# Altium schematic sheet DigitalPower.SchDoc
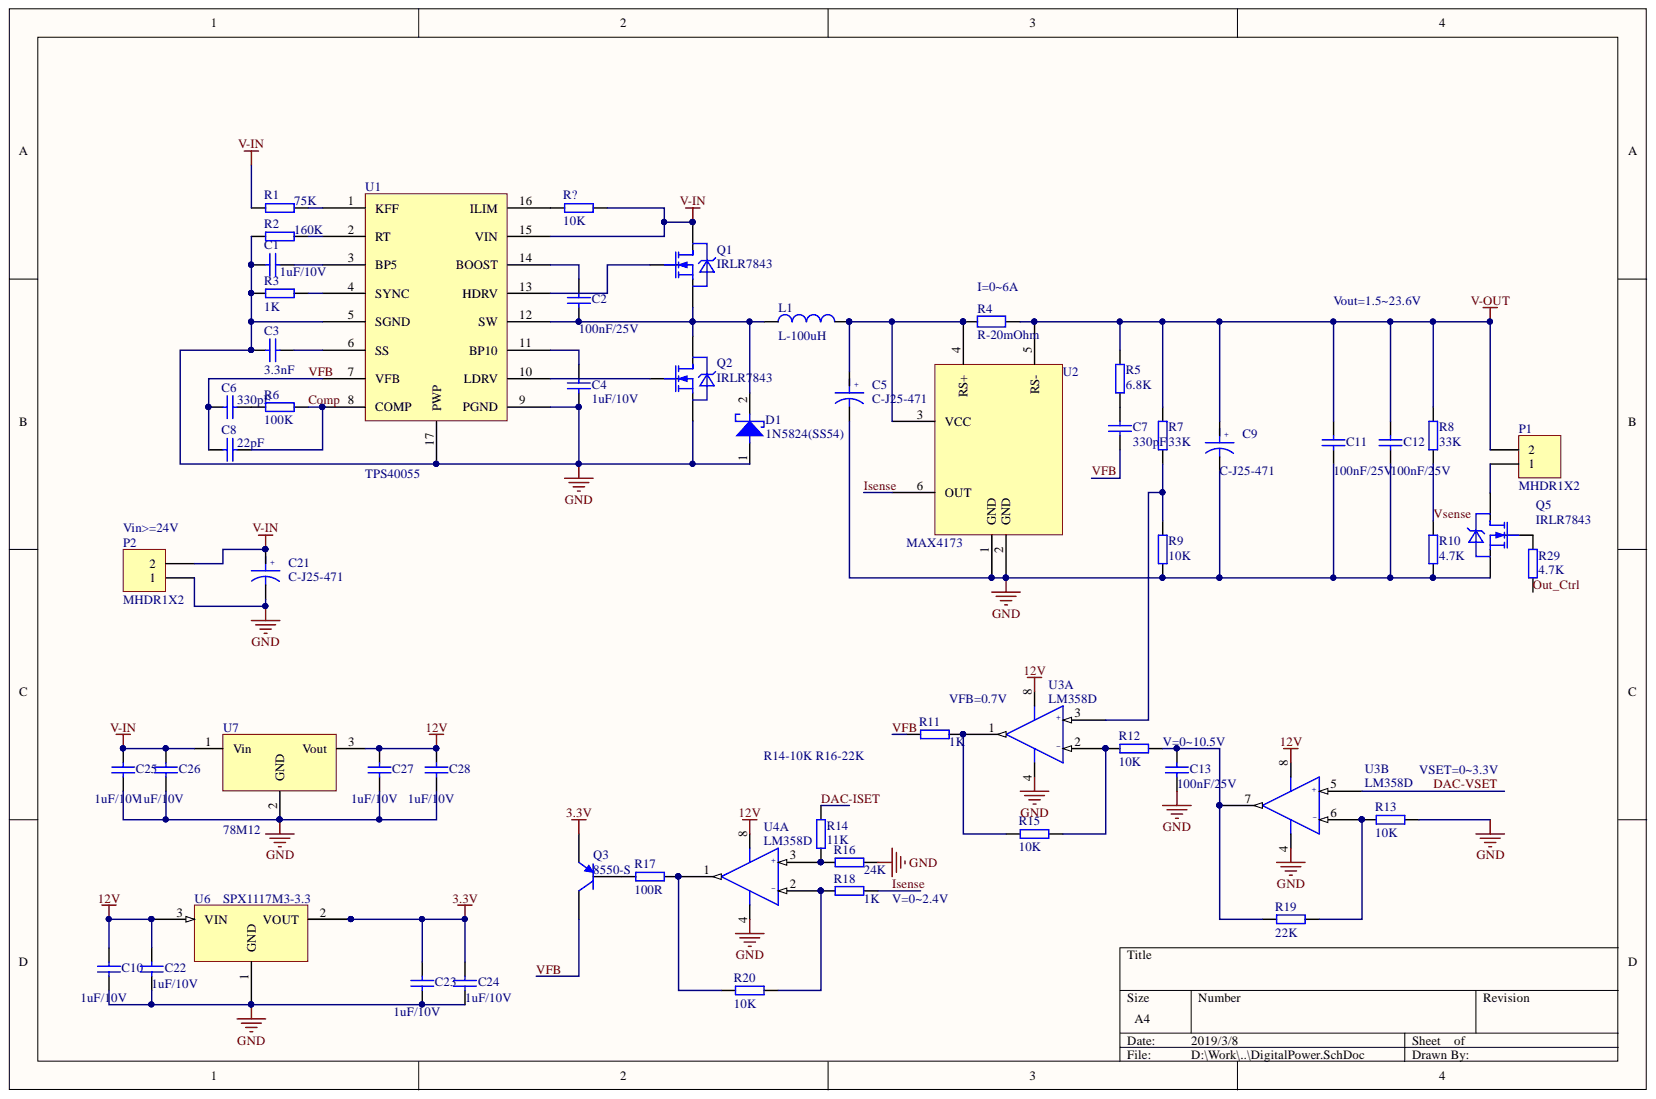
[diagram p0: DigitalPower.SchDoc]

## Parts
C1  1uF/10V  [0603-C]
C2  100nF/25V  [0603-C]
C3  3.3nF  [0603-C]
C4  1uF/10V  [0603-C]
C5  C-J25-471  [CJ-D10*20]
C6  330pF  [0603-C]
C7  330pF  [0603-C]
C8  22pF  [0603-C]
C9  C-J25-471  [CJ-D10*20]
C10  1uF/10V  [0603-C]
C11  100nF/25V  [0603-C]
C12  100nF/25V  [0603-C]
C13  100nF/25V  [0603-C]
C21  C-J25-471  [CJ-D10*20]
C22  1uF/10V  [0603-C]
C23  1uF/10V  [0603-C]
C24  1uF/10V  [0603-C]
C25  1uF/10V  [0603-C]
C26  1uF/10V  [0603-C]
C27  1uF/10V  [0603-C]
C28  1uF/10V  [0603-C]
D1  1N5824(SS54)  [DO-214AC]
L1  L-100uH  [HP2-2-4-6]
P1  MHDR1X2  [KF301-5.08-2P-10]
P2  MHDR1X2  [KF301-5.08-2P-10]
Q1  IRLR7843  [D-PAK]
Q2  IRLR7843  [D-PAK]
Q3  8550-S  [SOT-23-3]
Q5  IRLR7843  [D-PAK]
R1  75K  [0603-R]
R2  160K  [0603-R]
R3  1K  [0603-R]
R4  R-20mOhm  [R1210]
R5  6.8K  [0603-R]
R6  100K  [0603-R]
R7  33K  [0603-R]
R8  33K  [0603-R]
R9  10K  [0603-R]
R10  4.7K  [0603-R]
R11  1K  [0603-R]
R12  10K  [0603-R]
R13  10K  [0603-R]
R14  11K  [0603-R]
R15  10K  [0603-R]
R16  24K  [0603-R]
R17  100R  [0603-R]
R18  1K  [0603-R]
R19  22K  [0603-R]
R20  10K  [0603-R]
R29  4.7K  [0603-R]
R?  10K  [0603-R]
U1  TPS40055  [SSOP-EP-16(6.4-0.65)]
U2  MAX4173  [SOT-23-6]
U3  LM358D  [SOIC-8(6-1.27)]  (parts A,B)
U4  LM358D  [SOIC-8(6-1.27)]  (part A)
U6  SPX1117M3-3.3  [SOT-223-3]
U7  78M12  [D-PAK]

## Nets
3.3V: C23.2 C24.2 Q3.2 U6.2(VOUT) U6.4
12V: C10.2 C22.2 C27.2 C28.2 U3.8 U4.8 U6.3(VIN) U7.3
GND: C1.1 C3.2 C4.2 C5.2 C9.2 C10.1 C11.2 C12.2 C13.2 C21.2 C22.1 C23.1 C24.1 C25.1 C26.1 C27.1 C28.1 D1.1 P2.1 Q2.3 Q5.3 R2.2 R3.2 R9.1 R10.2 R13.2 R16.2 U1.5(SGND) U1.9(PGND) U1.17(PWP) U2.1(GND) U2.2(GND) U3.4 U4.4 U6.1(GND) U7.2
V-IN: C21.1 C25.2 C26.2 P2.2 Q1.2 R1.2 R?.2 U1.15(VIN) U7.1
V-OUT: C9.1 C11.1 C12.1 P1.2 R4.2 R5.2 R7.1 R8.1 U2.5(RS-)
COMP: C8.2 R6.1 U1.8(COMP)
DAC-ISET: R14.1
DAC-VSET: U3.5(+)
ISENSE: R18.1 U2.6(OUT)
OUT_CTRL: R29.2
VFB: C6.1 C7.1 C8.1 Q3.3 R11.2 U1.7(VFB)
VSENSE: R8.2 R10.1
NetC1_2: C1.2 U1.3(BP5)
NetC2_1: C2.1 U1.14(BOOST)
NetC2_2: C2.2 D1.2 L1.1 Q1.3 Q2.2 U1.12(SW)
NetC3_1: C3.1 U1.6(SS)
NetC4_1: C4.1 U1.11(BP10)
NetC5_1: C5.1 L1.2 R4.1 U2.3(VCC) U2.4(RS+)
NetC6_2: C6.2 R6.2
NetC7_2: C7.2 R5.1
NetC13_1: C13.1 R12.2 R19.2 U3.7
NetP1_1: P1.1 Q5.2
NetQ1_1: Q1.1 U1.13(HDRV)
NetQ2_1: Q2.1 U1.10(LDRV)
NetQ3_1: Q3.1 R17.2
NetQ5_1: Q5.1 R29.1
NetR1_1: R1.1 U1.1(KFF)
NetR2_1: R2.1 U1.2(RT)
NetR3_1: R3.1 U1.4(SYNC)
NetR7_2: R7.2 R9.2 U3.3(+)
NetR11_1: R11.1 R15.1 U3.1
NetR12_1: R12.1 R15.2 U3.2(-)
NetR13_1: R13.1 R19.1 U3.6(-)
NetR14_2: R14.2 R16.1 U4.3(+)
NetR17_1: R17.1 R20.1 U4.1
NetR18_2: R18.2 R20.2 U4.2(-)
NetR?_1: R?.1 U1.16(ILIM)

## Library data of the parts
C1 C4 C10 C22-C28 = 032075: Database Table Name: 陶瓷电容$; 产品型名: CC0603KRX5R6BB105(C0603-105K/10V); 品名: 电容器; 品牌: YAGEO; 封装: 0603; Component Kind: Standard; 存货编码: 032075; Library Name: LSD.DbLib
C2 C11-C13 = 032074: Database Table Name: 陶瓷电容$; 产品型名: CC0603KRX7R8BB104(C0603-104K/25V); 品名: 电容器; 品牌: YAGEO; 封装: 0603; Component Kind: Standard; 存货编码: 032074; Library Name: LSD.DbLib
C3 = 022659: Database Table Name: 陶瓷电容$; 产品型名: CC0603KRX7R9BB332(C0603-332K/50V); 品名: 电容器; 品牌: YAGEO; 封装: 0603; Component Kind: Standard; 存货编码: 022659; Library Name: LSD.DbLib
C5 C9 C21 = C-JXX-XXX: 电解电容; Component Kind: Standard; Library Name: 基本分立元件.SchLib
C6 C7 = 078634: Database Table Name: 陶瓷电容$; 产品型名: CC0603JRNPO9BN331; 品名: 电容器; 品牌: YAGEO; 封装: 0603; Component Kind: Standard; 存货编码: 078634; Library Name: LSD.DbLib
C8 = 026844: Database Table Name: 陶瓷电容$; 产品型名: CC0603JRNPO9BN220(C0603-22PJ/50V); 品名: 电容器; 品牌: YAGEO; 封装: 0603; Component Kind: Standard; 存货编码: 026844; Library Name: LSD.DbLib
D1 = 1N5824(SS54): 肖特基二极管; Component Kind: Standard; Library Name: 基本分立元件.SchLib
L1 = L-GXXX-X: 工字电感; Component Kind: Standard; Library Name: 基本分立元件.SchLib
P1 P2 = MHDR1X2: Header, 2-Pin; Component Kind: Standard; LatestRevisionDate: 17-Jul-2002; LatestRevisionNote: Re-released for DXP Platform.; Library Name: 连接器.SchLib; Publisher: Altium Limited
Q1 Q2 Q5 = IRFR310TRPBF: N沟道场效应管; Component Kind: Standard; Library Name: 基本分立元件.SchLib
Q3 = 8550-S: PNP三极管; Manufacturer: Generic Components; Class: Discrete; SubClass: BJT - Low Power; Component Kind: Standard; Published: 08/06/2000; Library Name: 基本分立元件.SchLib; Publisher: Altium Hobart Technology Centre
R1 = 030913: Database Table Name: 贴片电阻$; 产品型名: RC0603JR-0775KL; 品牌: YAGEO; 封装: 0603; Component Kind: Standard; 存货编码: 030913; Library Name: LSD.DbLib
R2 = 008721: Database Table Name: 贴片电阻$; 产品型名: RJ0603-160K; 品牌: Uniohm; 封装: 0603; Component Kind: Standard; 存货编码: 008721; Library Name: LSD.DbLib
R3 R11 R18 = 002979: Database Table Name: 贴片电阻$; 产品型名: RJ0603-1K; 品牌: Uniohm; 封装: 0603; Component Kind: Standard; 存货编码: 002979; Library Name: LSD.DbLib
R4 = R-XXXJ: 贴片电阻; Component Kind: Standard; Library Name: 基本分立元件.SchLib
R5 = 029070: Database Table Name: 贴片电阻$; 产品型名: RC0603JR-076K8L; 品牌: YAGEO; 封装: 0603; Component Kind: Standard; 存货编码: 029070; Library Name: LSD.DbLib
R6 = 005385: Database Table Name: 贴片电阻$; 产品型名: RJ0603-100K; 品牌: Uniohm; 封装: 0603; Component Kind: Standard; 存货编码: 005385; Library Name: LSD.DbLib
R7 R8 = 008735: Database Table Name: 贴片电阻$; 产品型名: RJ0603-33K; 品牌: Uniohm; 封装: 0603; Component Kind: Standard; 存货编码: 008735; Library Name: LSD.DbLib
R9 R12 R13 R15 R20 R? = 023845: Database Table Name: 贴片电阻$; 产品型名: RJ4D03-10K; 品牌: Uniohm; 封装: 0603; Component Kind: Standard; 存货编码: 023845; Library Name: LSD.DbLib
R10 R29 = 004090: Database Table Name: 贴片电阻$; 产品型名: RJ0603-4.7K; 品牌: Uniohm; 封装: 0603; Component Kind: Standard; 存货编码: 004090; Library Name: LSD.DbLib
R14 = 032801: Database Table Name: 贴片电阻$; 产品型名: RJ0603-11K; 品牌: Uniohm; 封装: 0603; Component Kind: Standard; 存货编码: 032801; Library Name: LSD.DbLib
R16 = 027657: Database Table Name: 贴片电阻$; 产品型名: RF0603-24K; 品牌: Uniohm; 封装: 0603; Component Kind: Standard; 存货编码: 027657; Library Name: LSD.DbLib
R17 = 004526: Database Table Name: 贴片电阻$; 产品型名: RJ0603-100R; 品牌: Uniohm; 封装: 0603; Component Kind: Standard; 存货编码: 004526; Library Name: LSD.DbLib
R19 = 031470: Database Table Name: 贴片电阻$; 产品型名: RC0603JR-0722KL; 品牌: YAGEO; 封装: 0603; Component Kind: Standard; 存货编码: 031470; Library Name: LSD.DbLib
U1 = TPS40055: Buck同步整流控制器; Component Kind: Standard; Library Name: 电源类.SchLib
U2 = MAX4173: 高端检流; Component Kind: Standard; Library Name: 集成杂类.SchLib
U3 U4 = LM358D: 2通道运放; Text Field1: 40; Component Kind: Standard; Text Field2: 40; Library Name: 门电路&运放.SchLib
U6 = SPX1117M3-3.3: 三端稳压; Text Field1: 100; Text Field2: 50; Component Kind: Standard; Library Name: 电源类.SchLib
U7 = 78M09: 三端稳压; Component Kind: Standard; Library Name: 电源类.SchLib
